# Altium schematic sheet B34.SchDoc(B34)
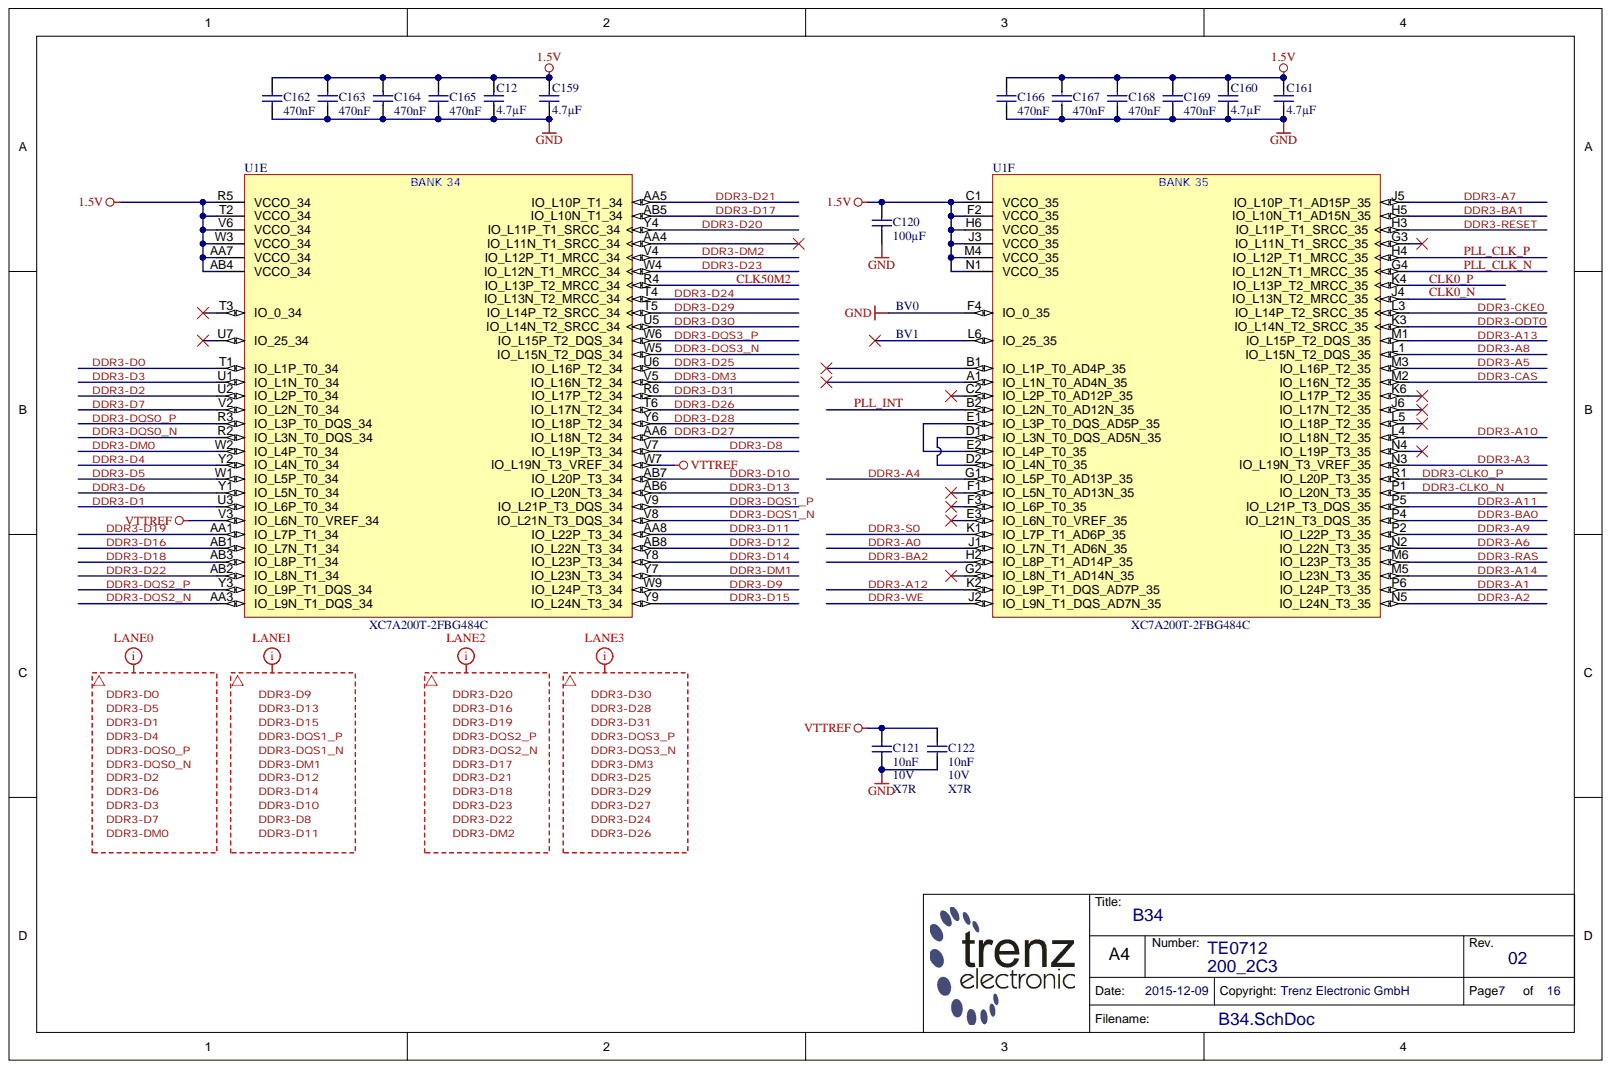
[diagram p0: B34.SchDoc]

## Parts
C12  4.7µF  [CAPC1005X60N]
C120  100µF  [CAPC3216X180N]
C121  10nF  Technology: X7R  Voltage: 10V  [CAPC0603X33N]
C122  10nF  Technology: X7R  Voltage: 10V  [CAPC0603X33N]
C159  4.7µF  [CAPC1005X60N]
C160  4.7µF  [CAPC1005X60N]
C161  4.7µF  [CAPC1005X60N]
C162  470nF  [CAPC0603X33N]
C163  470nF  [CAPC0603X33N]
C164  470nF  [CAPC0603X33N]
C165  470nF  [CAPC0603X33N]
C166  470nF  [CAPC0603X33N]
C167  470nF  [CAPC0603X33N]
C168  470nF  [CAPC0603X33N]
C169  470nF  [CAPC0603X33N]
U1  XC7A200T-2FBG484C  [BGA484C100P22X22_2300X2300X254N]  (parts E,F)

## Nets
1.5V: C12.1 C120.1 C159.1 C160.1 C161.1 C162.1 C163.1 C164.1 C165.1 C166.1 C167.1 C168.1 C169.1 U1.AA7(VCCO_34) U1.AB4(VCCO_34) U1.C1(VCCO_35) U1.F2(VCCO_35) U1.H6(VCCO_35) U1.J3(VCCO_35) U1.M4(VCCO_35) U1.N1(VCCO_35) U1.R5(VCCO_34) U1.T2(VCCO_34) U1.V6(VCCO_34) U1.W3(VCCO_34)
GND: C12.2 C120.2 C121.2 C122.2 C159.2 C160.2 C161.2 C162.2 C163.2 C164.2 C165.2 C166.2 C167.2 C168.2 C169.2 U1.F4(IO_0_35)
VTTREF: C121.1 C122.1 U1.V3(IO_L6N_T0_VREF_34) U1.W7(IO_L19N_T3_VREF_34)
CLK0_N: U1.J4(IO_L13N_T2_MRCC_35)
CLK0_P: U1.K4(IO_L13P_T2_MRCC_35)
CLK50M2: U1.R4(IO_L13P_T2_MRCC_34)
DDR3-A0: U1.J1(IO_L7N_T1_AD6N_35)
DDR3-A1: U1.P6(IO_L24P_T3_35)
DDR3-A2: U1.N5(IO_L24N_T3_35)
DDR3-A3: U1.N3(IO_L19N_T3_VREF_35)
DDR3-A4: U1.G1(IO_L5P_T0_AD13P_35)
DDR3-A5: U1.M3(IO_L16P_T2_35)
DDR3-A6: U1.N2(IO_L22N_T3_35)
DDR3-A7: U1.J5(IO_L10P_T1_AD15P_35)
DDR3-A8: U1.L1(IO_L15N_T2_DQS_35)
DDR3-A9: U1.P2(IO_L22P_T3_35)
DDR3-A10: U1.L4(IO_L18N_T2_35)
DDR3-A11: U1.P5(IO_L21P_T3_DQS_35)
DDR3-A12: U1.K2(IO_L9P_T1_DQS_AD7P_35)
DDR3-A13: U1.M1(IO_L15P_T2_DQS_35)
DDR3-A14: U1.M5(IO_L23N_T3_35)
DDR3-BA0: U1.P4(IO_L21N_T3_DQS_35)
DDR3-BA1: U1.H5(IO_L10N_T1_AD15N_35)
DDR3-BA2: U1.H2(IO_L8P_T1_AD14P_35)
DDR3-CAS: U1.M2(IO_L16N_T2_35)
DDR3-CKE0: U1.L3(IO_L14P_T2_SRCC_35)
DDR3-CLK0_N: U1.P1(IO_L20N_T3_35)
DDR3-CLK0_P: U1.R1(IO_L20P_T3_35)
DDR3-D0: U1.T1(IO_L1P_T0_34)
DDR3-D1: U1.U3(IO_L6P_T0_34)
DDR3-D2: U1.U2(IO_L2P_T0_34)
DDR3-D3: U1.U1(IO_L1N_T0_34)
DDR3-D4: U1.Y2(IO_L4N_T0_34)
DDR3-D5: U1.W1(IO_L5P_T0_34)
DDR3-D6: U1.Y1(IO_L5N_T0_34)
DDR3-D7: U1.V2(IO_L2N_T0_34)
DDR3-D8: U1.V7(IO_L19P_T3_34)
DDR3-D9: U1.W9(IO_L24P_T3_34)
DDR3-D10: U1.AB7(IO_L20P_T3_34)
DDR3-D11: U1.AA8(IO_L22P_T3_34)
DDR3-D12: U1.AB8(IO_L22N_T3_34)
DDR3-D13: U1.AB6(IO_L20N_T3_34)
DDR3-D14: U1.Y8(IO_L23P_T3_34)
DDR3-D15: U1.Y9(IO_L24N_T3_34)
DDR3-D16: U1.AB1(IO_L7N_T1_34)
DDR3-D17: U1.AB5(IO_L10N_T1_34)
DDR3-D18: U1.AB3(IO_L8P_T1_34)
DDR3-D19: U1.AA1(IO_L7P_T1_34)
DDR3-D20: U1.Y4(IO_L11P_T1_SRCC_34)
DDR3-D21: U1.AA5(IO_L10P_T1_34)
DDR3-D22: U1.AB2(IO_L8N_T1_34)
DDR3-D23: U1.W4(IO_L12N_T1_MRCC_34)
DDR3-D24: U1.T4(IO_L13N_T2_MRCC_34)
DDR3-D25: U1.U6(IO_L16P_T2_34)
DDR3-D26: U1.T6(IO_L17N_T2_34)
DDR3-D27: U1.AA6(IO_L18N_T2_34)
DDR3-D28: U1.Y6(IO_L18P_T2_34)
DDR3-D29: U1.T5(IO_L14P_T2_SRCC_34)
DDR3-D30: U1.U5(IO_L14N_T2_SRCC_34)
DDR3-D31: U1.R6(IO_L17P_T2_34)
DDR3-DM0: U1.W2(IO_L4P_T0_34)
DDR3-DM1: U1.Y7(IO_L23N_T3_34)
DDR3-DM2: U1.V4(IO_L12P_T1_MRCC_34)
DDR3-DM3: U1.V5(IO_L16N_T2_34)
DDR3-DQS0_N: U1.R2(IO_L3N_T0_DQS_34)
DDR3-DQS0_P: U1.R3(IO_L3P_T0_DQS_34)
DDR3-DQS1_N: U1.V8(IO_L21N_T3_DQS_34)
DDR3-DQS1_P: U1.V9(IO_L21P_T3_DQS_34)
DDR3-DQS2_N: U1.AA3(IO_L9N_T1_DQS_34)
DDR3-DQS2_P: U1.Y3(IO_L9P_T1_DQS_34)
DDR3-DQS3_N: U1.W5(IO_L15N_T2_DQS_34)
DDR3-DQS3_P: U1.W6(IO_L15P_T2_DQS_34)
DDR3-ODT0: U1.K3(IO_L14N_T2_SRCC_35)
DDR3-RAS: U1.M6(IO_L23P_T3_35)
DDR3-RESET: U1.H3(IO_L11P_T1_SRCC_35)
DDR3-S0: U1.K1(IO_L7P_T1_AD6P_35)
DDR3-WE: U1.J2(IO_L9N_T1_DQS_AD7N_35)
PLL_CLK_N: U1.G4(IO_L12N_T1_MRCC_35)
PLL_CLK_P: U1.H4(IO_L12P_T1_MRCC_35)
PLL_INT: U1.B2(IO_L2N_T0_AD12N_35)
NetU1_D1: U1.D1(IO_L3N_T0_DQS_AD5N_35) U1.D2(IO_L4N_T0_35)
NetU1_E1: U1.E1(IO_L3P_T0_DQS_AD5P_35) U1.E2(IO_L4P_T0_35)
N00486:
N00487:
N00488:
N00489:

Not connected: U1 A1(IO_L1N_T0_AD4N_35) AA4(IO_L11N_T1_SRCC_34) B1(IO_L1P_T0_AD4P_35) C2(IO_L2P_T0_AD12P_35) E3(IO_L6N_T0_VREF_35) F1(IO_L5N_T0_AD13N_35) F3(IO_L6P_T0_35) G2(IO_L8N_T1_AD14N_35) G3(IO_L11N_T1_SRCC_35) J6(IO_L17N_T2_35) K6(IO_L17P_T2_35) L5(IO_L18P_T2_35) L6(IO_25_35) N4(IO_L19P_T3_35) T3(IO_0_34) U7(IO_25_34)

## Library data of the parts
C12 C159-C161 = 24719: KON 4.7µF 6.3V X5R SMD 20%; Database Table Name: C_1005_0402; Mixed: SMT; Preferred: Yes; Value: 4.7u; Voltage: 6.3; AN: 24719; Case: 1005 (0402); Component Kind: Standard; Library Name: TE.DbLib; Technology: X5R; Tolerance: 20%
C120 = 23116: KON 100µF 6,3V X5R SMD 20%; Database Table Name: C_3216_1206; Mixed: SMT; Preferred: Yes; Value: 100u; Voltage: 6.3V; AN: 23116; Case: 3216 (1206); Component Kind: Standard; Library Name: TE.DbLib; Technology: X5R; Tolerance: 20%
C121 C122 = 26312: KON 10nF X7R 10V SMD 10%; Database Table Name: C_0603_0201; H-ART: GRM033R71A103KA01D; Mixed: SMT; Preferred: Yes; Value: 10n; AN: 26312; Case: 0603 (0201); Component Kind: Standard; Library Name: TE.DbLib; Tolerance: 10%
C162-C169 = 24921: KON 470nF X5R 6.3V SMD 20%; Database Table Name: C_0603_0201; Mixed: SMT; Preferred: Yes; Value: 470n; AN: 24921; Case: 0603 (0201); Component Kind: Standard; Library Name: TE.DbLib; Technology: X5R; Tolerance: 20%; Voltage: 6.3V
U1 = 25321: Database Table Name: PROG_LOGIC; Mixed: SMT; Trenz-Stock: Yes; AN: 25321; Case: BGA484; Component Kind: Standard; Description: XC7A200T-2FBG484C; H-ART: XC7A200T-2FBG484C; Library Name: TE.DbLib; HelpURL: B:\AD\adlib\datasheet\XC7
